# Altium schematic sheet 06_POWER-INPUT.SchDoc
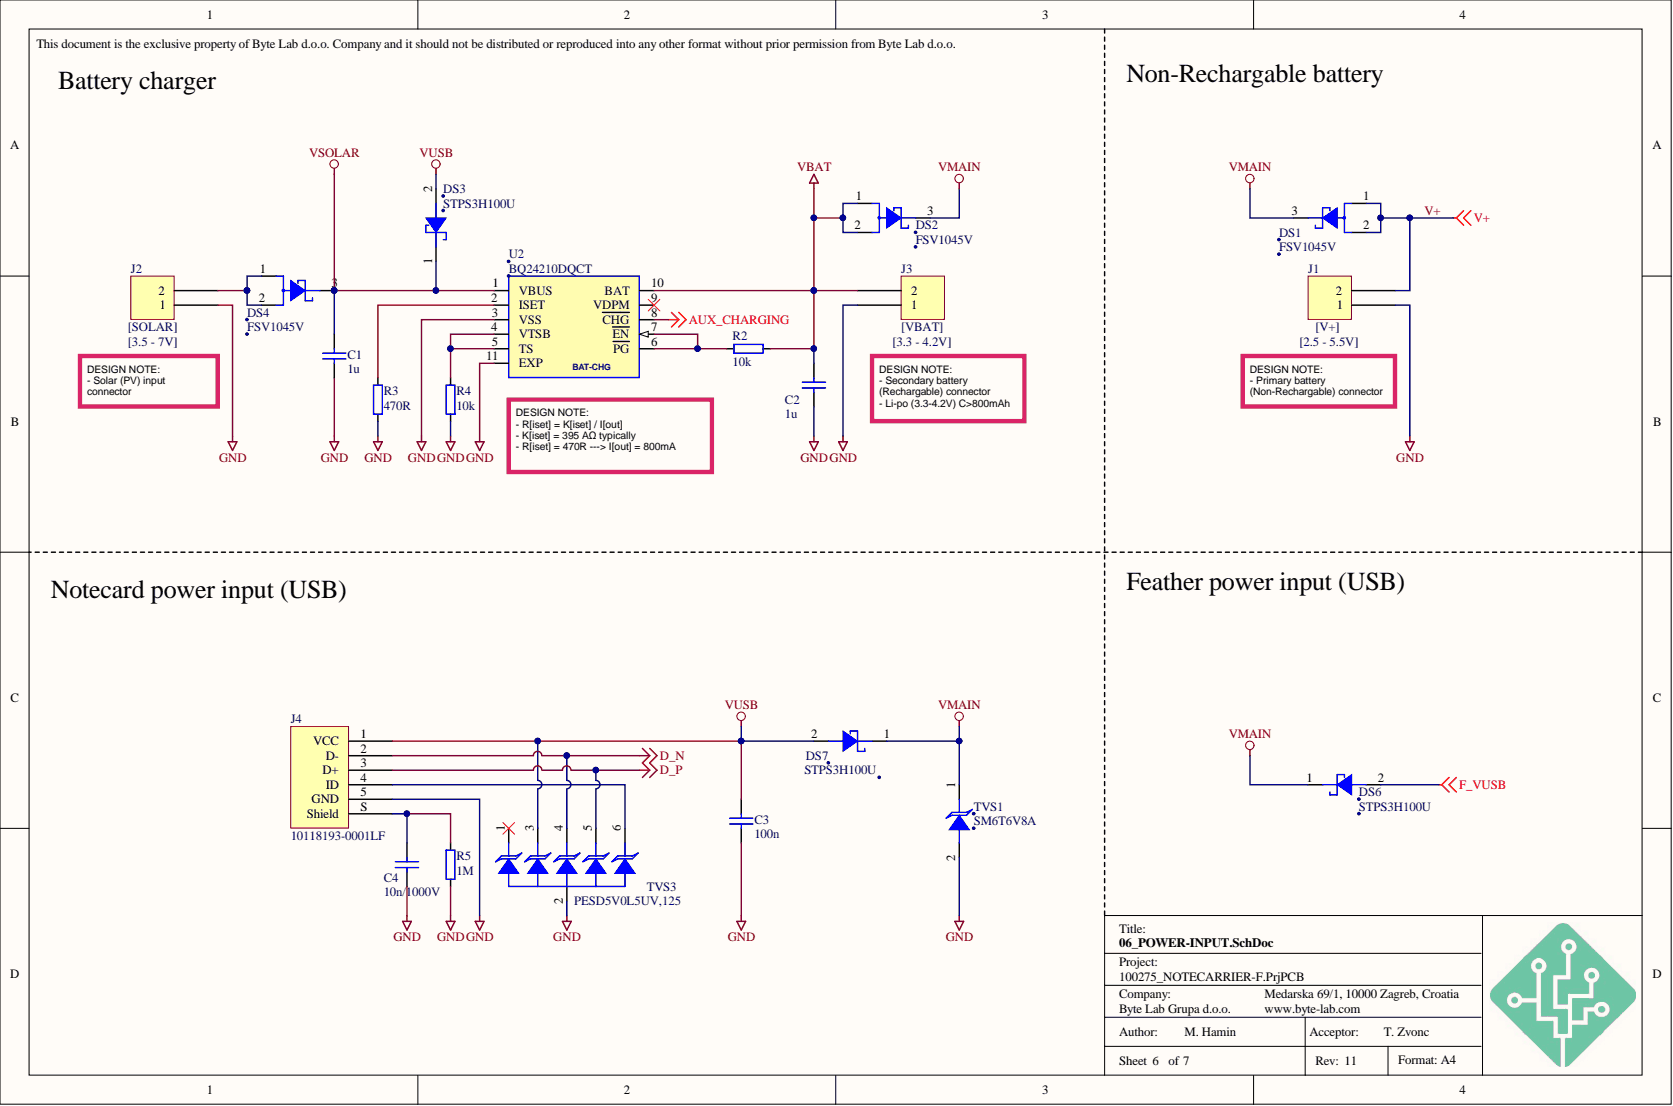
[diagram p0: 06_POWER-INPUT.SchDoc]

## Parts
C1  1u  [C-0603]
C2  1u  [C-0603]
C3  100n  [C-0402]
C4  10n/1000V  [C-1206]
DS1  FSV1045V  [TO277-3]
DS2  FSV1045V  [TO277-3]
DS3  STPS3H100U  [SMB]
DS4  FSV1045V  [TO277-3]
DS6  STPS3H100U  [SMB]
DS7  STPS3H100U  [SMB]
J1  S2BPHSM4TBLFSN  [JST S2B-PH-SM4-TB]  (value not drawn)
J2  S2BPHSM4TBLFSN  [JST S2B-PH-SM4-TB]  (value not drawn)
J3  S2BPHSM4TBLFSN  [JST S2B-PH-SM4-TB]  (value not drawn)
J4  10118193-0001LF  [FCI USB 10118193-0001LF]
R2  10k  [R-0402]
R3  470R  [R-0402]
R4  10k  [R-0402]
R5  1M  [R-1206]
TVS1  SM6T6V8A  [SMB]
TVS3  PESD5V0L5UV,125  [SOT666]
U2  BQ24210DQCT  [WSON10-EXP]

## Nets
AUX_CHARGING: U2.8(CHG)
D_N: J4.2(D-) TVS3.4
D_P: J4.3(D+) TVS3.5
F_VUSB: DS6.2
GND: C1.1 C2.1 C3.2 C4.2 J1.1 J2.1 J3.1 J4.5(GND) R3.1 R4.1 R5.2 TVS1.2 TVS3.2 U2.3(VSS) U2.11(EXP)
VBAT: C2.2 DS2.1 DS2.2 J3.2 R2.2 U2.10(BAT)
VMAIN: DS1.3 DS2.3 DS6.1 DS7.1 TVS1.1
VSOLAR: C1.2 DS3.1 DS4.3 U2.1(VBUS)
VUSB: C3.1 DS3.2 DS7.2 J4.1(VCC) TVS3.3
V+: DS1.1 DS1.2 J1.2
NetC4_1: C4.1 J4.S(Shield) R5.1
NetDS4_1: DS4.1 DS4.2 J2.2
NetJ4_4: J4.4(ID) TVS3.6
NetR2_1: R2.1 U2.6(PG) U2.7(EN)
NetR3_2: R3.2 U2.2(ISET)
NetR4_2: R4.2 U2.4(VTSB) U2.5(TS)

Not connected: TVS3 1; U2 9(VDPM)

## Library data of the parts
C1 C2 = C0603-1u-25V-X5R: Capacitor ceramic 1u ±10% X5R 25V; BL Part Number: 2006-223; Manufacturer: Yageo; Manufacturer Part Number: CC0603KRX5R8BB105; Checked_By: Golubic; Drawn_By: Hamin; Component Kind: Standard; DateModified: 2022-10-07; Library Name: BL_Passive.SchLib; Supplier 1: Digi-Key; Supplier Part Number 1: 311-1445-1-ND
C3 = C0402-100n-16V-X7R: Capacitor ceramic 100n ±10% X7R 16V 0402; BL Part Number: 2000-908; Checked_By: Dodig; Drawn_By: Puskar; Component Kind: Standard; DateModified: 27-08-2013; Library Name: BL_Passive.SchLib; Manufacturer: N/A; Manufacturer Part Number: N/A; Simulation: CAP; Supplier 1: Digi-Key; Supplier Part Number 1: 490-3261-1-ND
C4 = C1206-10n-1000V-X7R - CS1206KKX7RCBB103: Capacitor ceramic 10n ±10% X7R 1000V 1206; BL Part Number: 2007-449; Manufacturer: YAGEO; Drawn_By: Hamin; Component Kind: Standard; DateModified: 03-05-2023; Library Name: BL_Passive.SchLib; Manufacturer Part Number: CS1206KKX7RCBB103; Supplier 1: Digi-Key; Supplier Part Number 1: 311-3578-1-ND
DS1 DS2 DS4 = SCHOTTKY - FSV1045V - 10A/45V: DIODO SCHOTTKY 10A 45V FSV1045V TO277-3 SMT; BL Part Number: 2007-712; Manufacturer: onsemi; Drawn_By: Blues Wireless; Component Kind: Standard; Library Name: n21-p2.SchLib; Manufacturer Part Number: FSV1045V; Supplier 1: Digi-Key; Supplier Part Number 1: FSV1045VCT-ND
DS3 DS6 DS7 = SCHOTTKY-STPS3H100U - 3A/100V: DIODO SCHOTTKY 3A 100V STPS3H100U SMB SMT; BL Part Number: 2007-711; Manufacturer: STMicroelectronics; Drawn_By: Blues Wireless; Component Kind: Standard; Library Name: n21-p2.SchLib; Manufacturer Part Number: STPS3H100U; Supplier 1: Digi-Key; Supplier Part Number 1: 497-10392-1-ND
J1-J3 = S2BPHSM4TBLFSN: PH SMT SIDE ENTRY HDR 2W; BL Part Number: 2007-662; Manufacturer: JST; Manufacturer Part Number: S2B-PH-SM4-K-TB (LF)(SN); Drawn_By: Blues Wireless; Component Kind: Standard; Library Name: n21-p2.SchLib; Supplier 1: Digi-Key
J4 = USB 2.0 Type B Micro: CONN RCPT USB2.0 MICRO B SMD R/A; BL Part Number: 2007-454; Manufacturer: Amphenol ICC (FCI); Component Kind: Standard; Library Name: n21-p2.SchLib; Manufacturer Part Number: 10118193-0001LF; Supplier 1: Digi-Key; Supplier Part Number 1: 609-4616-2-ND
R2 R4 = R0402-10k-1: Resistor 10k ±1% 0402; BL Part Number: 2000-729; Checked_By: Dodig; Drawn_By: Puskar; Component Kind: Standard; DateModified: 27-08-2013; Library Name: BL_Passive.SchLib; Manufacturer: N/A; Manufacturer Part Number: N/A; Supplier 1: Digi-Key; Supplier Part Number 1: 311-10.0KLRCT-ND
R3 = R0402-470R-1: Resistor 470R ±1% 0402; BL Part Number: 2001-420; Drawn_By: Hamin; Component Kind: Standard; DateModified: 10-07-2023; Library Name: BL_Passive.SchLib; Manufacturer: N/A; Manufacturer Part Number: N/A; Supplier 1: Digi-Key; Supplier Part Number 1: 13-RC0402FR-13470RLCT-ND
R5 = R1206-1M-1: Resistor 1M ±1% 1206; BL Part Number: 2001-438; Checked_By: Golubic; Drawn_By: Zvonc; Component Kind: Standard; DateModified: 01-07-2014; Library Name: BL_Passive.SchLib; Manufacturer: N/A; Manufacturer Part Number: N/A
TVS1 = TVS-UNI - SM6T6V8A - 6.8V/600W: DIODO TVS TRANSIL UNIDIR. 600W 6.8V SM6T6V8A SMB SMT; BL Part Number: 2007-453; Manufacturer: STMicroelectronics; Drawn_By: Blues Wireless; Component Kind: Standard; Library Name: n21-p2.SchLib; Manufacturer Part Number: SM6T6V8A; Supplier 1: Digi-Key; Supplier Part Number 1: 497-6501-1-ND
TVS3 = PESD5V0L5UV,125: PESD Series 25 W 5 V Uni-Directional Fivefold ESD Protection Diode Array SOT-666; BL Part Number: 2007-452; Manufacturer: Nexperia; Drawn_By: Blues Wireless; Component Kind: Standard; Library Name: n21-p2.SchLib; Manufacturer Part Number: PESD5V0L5UV,125; Supplier 1: Digi-Key; Supplier Part Number 1: 1727-5797-1-ND
U2 = BAT-CHARGE - BQ24210DQCT: INTEGRATO BQ24210DQCT WSON10 TEXAS SMT; BL Part Number: 2005-527; Manufacturer: Texas Instruments; Drawn_By: Blues Wireless; Component Kind: Standard; Library Name: n21-p2.SchLib; Manufacturer Part Number: BQ24210DQCT; Supplier 1: Digi-Key; Supplier Part Number 1: 296-28738-1-ND
